# Altium schematic sheet Perif.SchDoc(Perif)
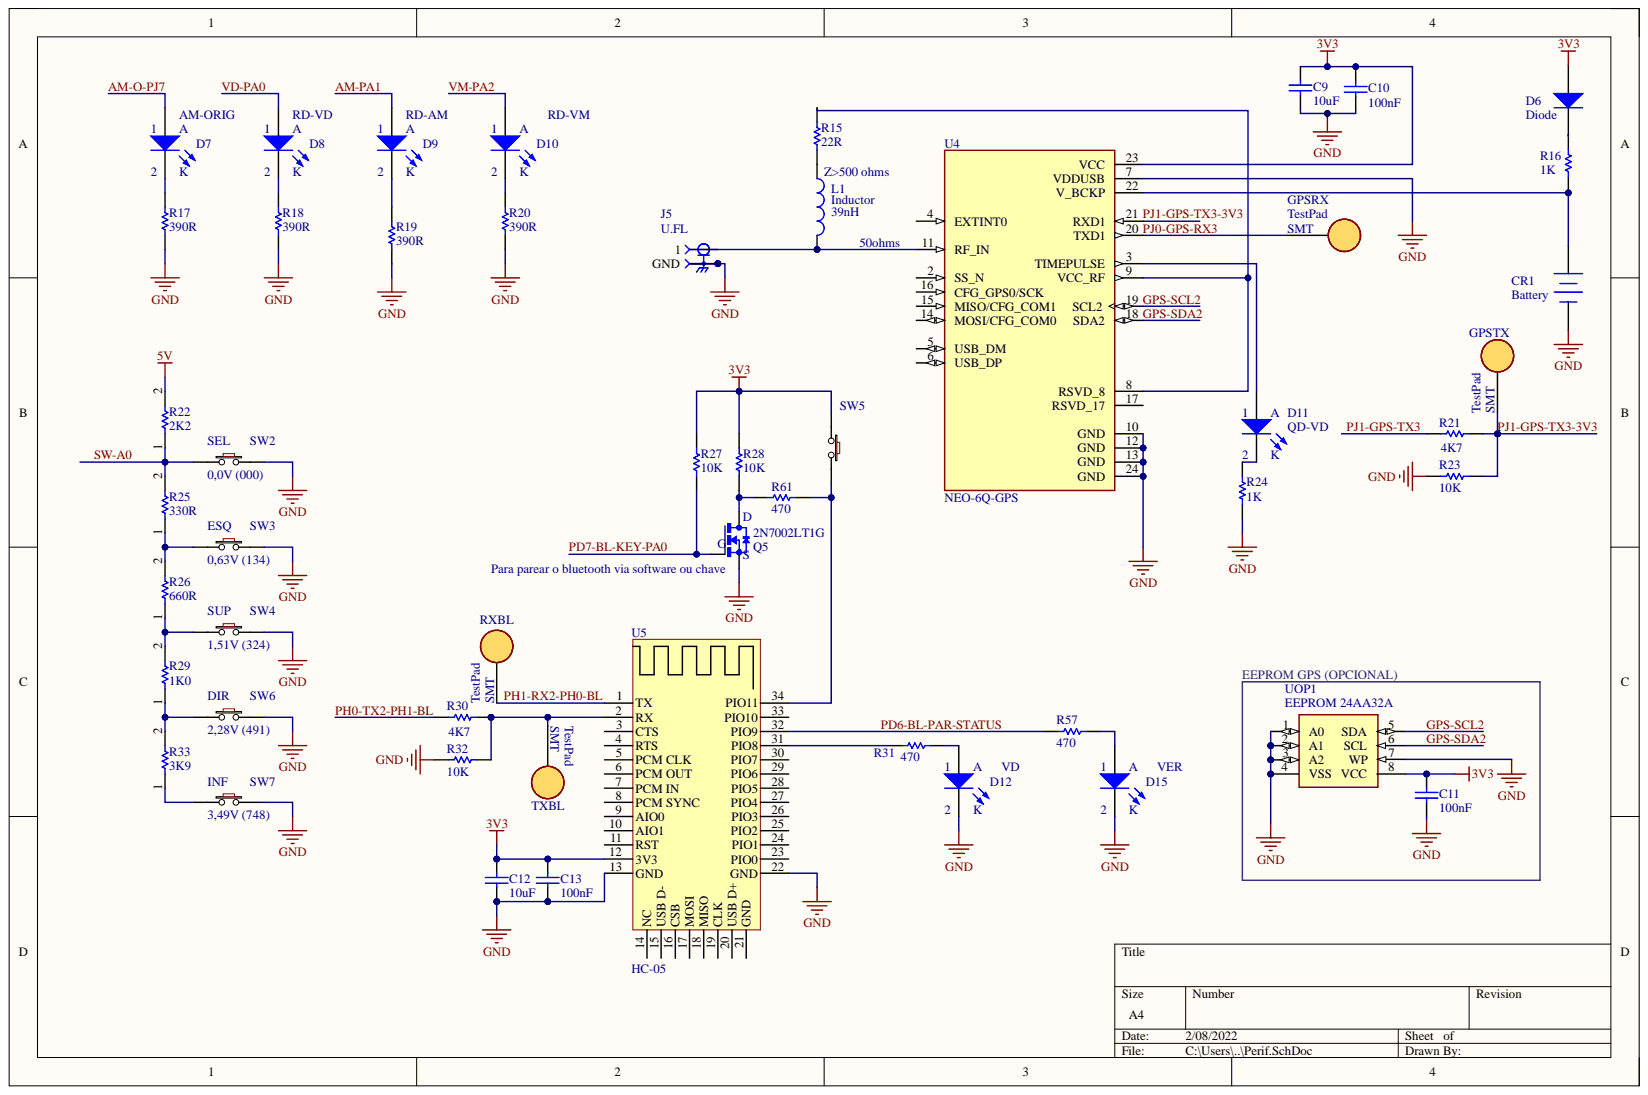
[diagram p0: Perif.SchDoc]

## Parts
C9  10uF  [CAPGC2012X65N]
C10  100nF  [CAPC2012X65N]
C11  100nF  [CAPC2012X65N - unpopulated]
C12  10uF  [CAPGC2012X65N]
C13  100nF  [CAPC2012X65N]
CR1  Battery  [BAT_BC501SM]
D6  Diode  [DIOM5436X245N]
D7  AM-ORIG  [LEDTH-AZUL]
D8  RD-VD  [LEDTH-VERD]
D9  RD-AM  [LEDTH-AM]
D10  RD-VM  [LEDTH-VERM]
D11  QD-VD  [LED_7012X1]
D12  VD  [LED_7012X1]
D15  VER  [LED_7012X1]
GPSRX  (no value)  [TestPAD-SMT-SIMPLES]
GPSTX  (no value)  [TestPAD-SMT-SIMPLES]
J5  U.FL  [SAMTEC_RSP-122811-01]
L1  Inductor  value 39nH  [RESC2012X65N - AZUL]
Q5  2N7002LT1G  [SOT23]
R15  22R  [RESC2012X65N]
R16  1K  [RESC2012X65N]
R17  390R  [RESC2012X65N]
R18  390R  [RESC2012X65N]
R19  390R  [RESC2012X65N]
R20  390R  [RESC2012X65N]
R21  4K7  [RESC2012X65N]
R22  2K2  [RESC2012X65N]
R23  10K  [RESC2012X65N]
R24  1K  [RESC2012X65N]
R25  330R  [RESC2012X65N]
R26  660R  [RESC2012X65N]
R27  10K  [RESC2012X65N]
R28  10K  [RESC2012X65N]
R29  1K0  [RESC2012X65N]
R30  4K7  [RESC2012X65N]
R31  470  [RESC2012X65N]
R32  10K  [RESC2012X65N]
R33  3K9  [RESC2012X65N]
R57  470  [RESC2012X65N]
R61  470  [RESC2012X65N]
RXBL  (no value)  [TestPAD-SMT-SIMPLES]
SW2  PUSH BUTTON  [SW_PTS636_SM43J_SMTR_LFS]  (value not drawn)
SW3  PUSH BUTTON  [SW_PTS636_SM43J_SMTR_LFS]  (value not drawn)
SW4  PUSH BUTTON  [SW_PTS636_SM43J_SMTR_LFS]  (value not drawn)
SW5  PUSH BUTTON  [SW_PTS636_SM43J_SMTR_LFS]  (value not drawn)
SW6  PUSH BUTTON  [SW_PTS636_SM43J_SMTR_LFS]  (value not drawn)
SW7  PUSH BUTTON  [SW_PTS636_SM43J_SMTR_LFS]  (value not drawn)
TXBL  (no value)  [TestPAD-SMT-SIMPLES]
U4  NEO-6Q-GPS  [XCVR_NEO-6M-GPS]
U5  HC-05  [XCVR_HC-05]
UOP1  24AA32A-I/MS  [SOP65P490X110-8N]  (value not drawn)

## Nets
3V3: C9.1 C10.1 C11.1 C12.1 C13.1 D6.A R27.1 R28.2 SW5.1 U4.23(VCC) U5.12(3V3) UOP1.8(VCC)
5V: R22.2
GND: C9.2 C10.2 C11.2 C12.2 C13.2 CR1.N D12.C D15.C J5.G1 J5.G2 Q5.2 R17.1 R18.1 R19.1 R20.1 R23.2 R24.2 R32.2 SW2.2 SW3.2 SW4.2 SW6.2 SW7.2 U4.7(VDDUSB) U4.10(GND) U4.12(GND) U4.13(GND) U4.24(GND) U5.13(GND) U5.22(GND) UOP1.1(A0) UOP1.2(A1) UOP1.3(A2) UOP1.4(VSS) UOP1.7(WP)
AM-O-PJ7: D7.A
AM-PA1: D9.A
GPS-SCL2: U4.19(SCL2) UOP1.5(SDA)
GPS-SDA2: U4.18(SDA2) UOP1.6(SCL)
PD6-BL-PAR-STATUS: R57.2 U5.32(PIO9)
PD7-BL-KEY-PA0: Q5.1 R27.2
PH0-TX2-PH1-BL: R30.1
PH1-RX2-PH0-BL: RXBL.1 U5.1(TX)
PJ0-GPS-RX3: GPSRX.1 U4.20(TXD1)
PJ1-GPS-TX3: R21.1
PJ1-GPS-TX3-3V3: GPSTX.1 R21.2 R23.1 U4.21(RXD1)
SW-A0: R22.1 R25.2 SW2.1
VD-PA0: D8.A
VM-PA2: D10.A
NetCR1_P: CR1.P R16.2 U4.22(V_BCKP)
NetD6_C: D6.C R16.1
NetD7_C: D7.C R17.2
NetD8_C: D8.C R18.2
NetD9_C: D9.C R19.2
NetD10_C: D10.C R20.2
NetD11_A: D11.A U4.3(TIMEPULSE)
NetD11_C: D11.C R24.1
NetD12_A: D12.A R31.1
NetD15_A: D15.A R57.1
NetJ5_1: J5.1 L1.2 U4.11(RF_IN)
NetL1_1: L1.1 R15.2
NetQ5_3: Q5.3 R28.1 R61.2
NetR15_1: R15.1 U4.8(RSVD_8) U4.9(VCC_RF)
NetR25_1: R25.1 R26.2 SW3.1
NetR26_1: R26.1 R29.2 SW4.1
NetR29_1: R29.1 R33.2 SW6.1
NetR30_2: R30.2 R32.1 TXBL.1 U5.2(RX)
NetR31_2: R31.2 U5.31(PIO8)
NetR33_1: R33.1 SW7.1
NetR61_1: R61.1 SW5.2 U5.34(PIO11)

Not connected: U4 2(SS_N) 4(EXTINT0) 5(USB_DM) 6(USB_DP) 14(MOSI/CFG_COM0) 15(MISO/CFG_COM1) 16(CFG_GPS0/SCK) 17(RSVD_17); U5 3(CTS) 4(RTS) 5(PCM_CLK) 6(PCM_OUT) 7(PCM_IN) 8(PCM_SYNC) 9(AIO0) 10(AIO1) 11(RST) 14(NC) 15(USB_D-) 16(CSB) 17(MOSI) 18(MISO) 19(CLK) 20(USB_D+) 21(GND) 23(PIO0) 24(PIO1) 25(PIO2) 26(PIO3) 27(PIO4) 28(PIO5) 29(PIO6) 30(PIO7) 33(PIO10)

## Library data of the parts
C9 C12 = Cap: Capacitor; Value: 100nF; Component Kind: Standard; LatestRevisionDate: 17-Jul-2002; LatestRevisionNote: Re-released for DXP Platform.; Library Name: Miscellaneous Devices.IntLib; PackageDescription: Radial Cap, Thru-Hole; 2 Leads; 0.3 in Pin Spacing; PackageReference: RAD-0.3; Published: 8-Jun-2000; Publisher: Altium Limited
C10 C11 C13 = Cap: Capacitor; Component Kind: Standard; LatestRevisionDate: 17-Jul-2002; LatestRevisionNote: Re-released for DXP Platform.; Library Name: Miscellaneous Devices.IntLib; PackageDescription: Radial Cap, Thru-Hole; 2 Leads; 0.3 in Pin Spacing; PackageReference: RAD-0.3; Published: 8-Jun-2000; Value: 100nF; Publisher: Altium Limited
CR1 = Battery: Multicell Battery; Component Kind: Standard; LatestRevisionDate: 17-Jul-2002; LatestRevisionNote: Re-released for DXP Platform.; Library Name: Miscellaneous Devices.IntLib; PackageDescription: Battery; 2 Leads; PackageReference: BAT-2; Published: 24-Mar-1999; Publisher: Altium Limited
D6 = Diode: Default Diode; Code_JEDEC: DO-214-AB; Component Kind: Standard; LatestRevisionDate: 17-Jul-2002; LatestRevisionNote: Re-released for DXP Platform.; Library Name: Miscellaneous Devices.IntLib; PackageDescription: DO-214-AB/SMC; 2 C-Bend Leads; Body 7.9 x 5.9 mm, inc. leads (LxW); PackageReference: SMC; PackageVersion: Sep-1996; Published: 8-Jun-2000; Signal Integrity: Diode; Simulation: DIODE; Publisher: Altium Limited
D7-D12 D15 = LED: Component Kind: Standard; Library Name: Zele_Sch.SchLib
GPSRX GPSTX RXBL TXBL = TestPad: Component Kind: Standard; Library Name: TestPadSMT.SchLib
J5 = RSP-122811-01: U.FL (UMCC), IPEX MHF1 Connector Receptacle, Male Pin 50Ohm Surface Mount Solder; MF: Samtec Inc.; Availability: Warning; Component Kind: Standard; Library Name: U.FL-R.SchLib; MP: RSP-122811-01; Package: None; Price: None; Purchase-URL: https://pricing.snapeda.com/search/part/RSP-122811-01/?ref=eda; SnapEDA_Link: https://www.snapeda.com/parts/RSP-122811-01/Samtec%20Inc./view-part/1051901/?ref=snap
L1 = Inductor: Code_IEC: 1005; Code_JEITA: 0402; Component Kind: Standard; Description: Inductor; LatestRevisionDate: 29-May-2009; LatestRevisionNote: IPC-7351 Footprint Added.; Library Name: Miscellaneous Devices.IntLib; PackageDescription: Chip Inductor; PackageReference: 0402-A; Published: 8-Jun-2000; Signal Integrity: Inductor; Simulation: INDUCTOR; Publisher: Altium Limited
Q5 = FDN359AN: N-Channel 30V 2.7A (Ta) 500mW (Ta) Surface Mount SuperSOT-3; MF: ON Semiconductor; Component Kind: Standard; Digi-Key_Part_Number: FDN359ANCT-ND; MP: FDN359AN; Package: SC-59 ON Semiconductor; SnapEDA_Link: https://www.snapeda.com/parts/FDN359AN/ON%20Semiconductor/view-part/1737161/?ref=snap; Library Name: NMOS-SOT23.SchLib
R15 R16 R24 R27 R28 R31 R57 R61 = Res1: Resistor; Value: 4K7; Component Kind: Standard; LatestRevisionDate: 17-Jul-2002; LatestRevisionNote: Re-released for DXP Platform.; Library Name: Miscellaneous Devices.IntLib; PackageDescription: Axial Device, Thru-Hole; 2 Leads; 0.3 in Pin Spacing; PackageReference: AXIAL-0.3; Published: 8-Jun-2000; Signal Integrity: Res; Simulation: RESISTOR; Publisher: Altium Limited
R17-R20 = Res1: Resistor; Value: 220; Component Kind: Standard; LatestRevisionDate: 17-Jul-2002; LatestRevisionNote: Re-released for DXP Platform.; Library Name: Miscellaneous Devices.IntLib; PackageDescription: Axial Device, Thru-Hole; 2 Leads; 0.3 in Pin Spacing; PackageReference: AXIAL-0.3; Published: 8-Jun-2000; Signal Integrity: Res; Simulation: RESISTOR; Publisher: Altium Limited
R21 R23 R30 R32 = Res1: Resistor; Value: 47K; Component Kind: Standard; LatestRevisionDate: 17-Jul-2002; LatestRevisionNote: Re-released for DXP Platform.; Library Name: Miscellaneous Devices.IntLib; PackageDescription: Axial Device, Thru-Hole; 2 Leads; 0.3 in Pin Spacing; PackageReference: AXIAL-0.3; Published: 8-Jun-2000; Signal Integrity: Res; Simulation: RESISTOR; Publisher: Altium Limited
R22 R26 R29 R33 = Res1: Resistor; Component Kind: Standard; LatestRevisionDate: 17-Jul-2002; LatestRevisionNote: Re-released for DXP Platform.; Library Name: Miscellaneous Devices.IntLib; PackageDescription: Axial Device, Thru-Hole; 2 Leads; 0.3 in Pin Spacing; PackageReference: AXIAL-0.3; Published: 8-Jun-2000; Signal Integrity: Res; Simulation: RESISTOR; Publisher: Altium Limited
  R22: Value: 2K2
  R26: Value: 660R
  R29: Value: 1K0
  R33: Value: 3K9
R25 = Res1: Resistor; Value: 1K; Component Kind: Standard; LatestRevisionDate: 17-Jul-2002; LatestRevisionNote: Re-released for DXP Platform.; Library Name: Miscellaneous Devices.IntLib; PackageDescription: Axial Device, Thru-Hole; 2 Leads; 0.3 in Pin Spacing; PackageReference: AXIAL-0.3; Published: 8-Jun-2000; Signal Integrity: Res; Simulation: RESISTOR; Publisher: Altium Limited
SW2-SW7 = PUSHB: Component Kind: Standard; Library Name: Zele_Sch.SchLib
U4 = NEO-6M-GPS: SMD GPS Module;; MF: u-blox; Availability: Unavailable; Component Kind: Standard; Library Name: NEO6M-GPS.SchLib; MP: NEO-6M-GPS; Package: None; Price: None; SnapEDA_Link: https://www.snapeda.com/parts/NEO-6M-GPS/u-blox/view-part/105879/?ref=snap
U5 = HC-05 Raw: Component Kind: Standard; Description: HC-05 Raw; Library Name: Zele_Sch.SchLib
UOP1 = 24AA32A-I/MS: EEPROM Memory IC 32Kb _4K x 8_ IÂ²C 400kHz 900ns 8-MSOP; MF: Microchip; Availability: Unavailable; Component Kind: Standard; Library Name: GPS EEPROM.SchLib; MP: 24AA32A-I/MS; Package: MSOP-8 Microchip; Price: None; SnapEDA_Link: https://www.snapeda.com/parts/24AA32A-I/MS/Microchip/view-part/138275/?ref=snap
